# Altium schematic sheet Bumblebee.SchDoc
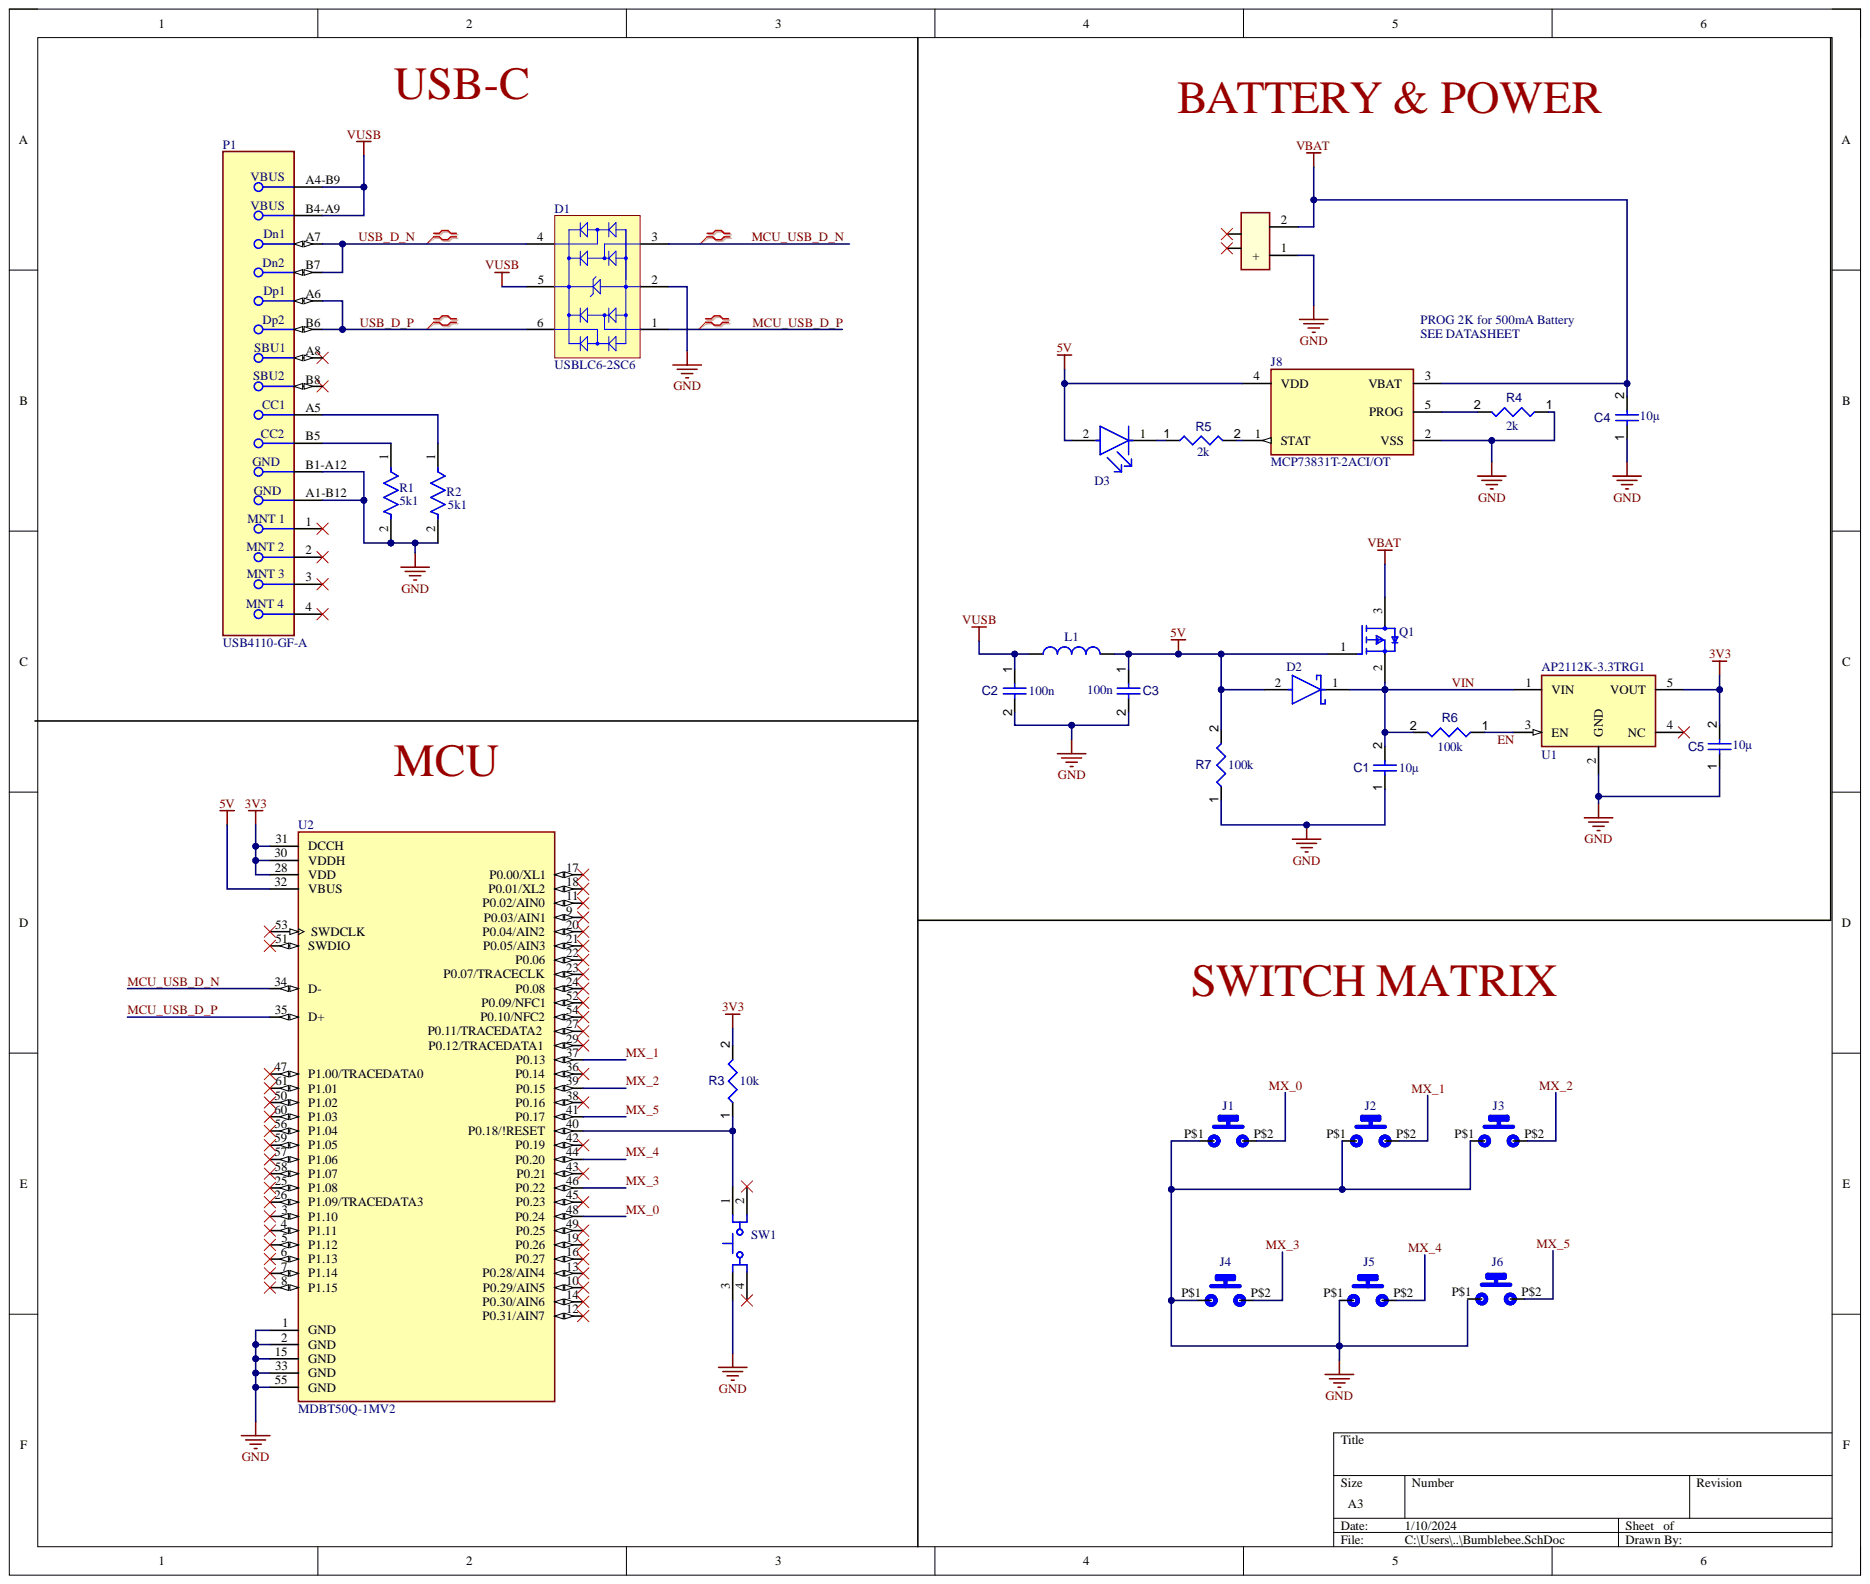
[diagram p0: Bumblebee.SchDoc]

## Parts
C1  value 10µ  [CAPC0603(1608)100_L]  (hidden comment: Capacitor 10µF +/-20% 10V 0603)
C2  value 100n  [CAPC1608X90X40NL10T20]  (hidden comment: Capacitor 100 nF +/-10% 50 V 0603)
C3  value 100n  [CAPC1608X90X40NL10T20]  (hidden comment: Capacitor 100 nF +/-10% 50 V 0603)
C4  value 10µ  [CAPC0603(1608)100_L]  (hidden comment: Capacitor 10µF +/-20% 10V 0603)
C5  value 10µ  [CAPC0603(1608)100_L]  (hidden comment: Capacitor 10µF +/-20% 10V 0603)
D1  USBLC6-2SC6  [STM-SOT23-6L_V]
D2  MBR120ESFT1G  [On_Semiconductor_498_MFG]  (value not drawn)
D3  APHCM2012SYCK-F01  [FP-APHCM2012SYCK-F01-MFG]  (value not drawn)
J1  MX1A-11NN  [CHERRY_MX1A-11NN_SWITCH]  (value not drawn)
J2  MX1A-11NN  [CHERRY_MX1A-11NN_SWITCH]  (value not drawn)
J3  MX1A-11NN  [CHERRY_MX1A-11NN_SWITCH]  (value not drawn)
J4  MX1A-11NN  [CHERRY_MX1A-11NN_SWITCH]  (value not drawn)
J5  MX1A-11NN  [CHERRY_MX1A-11NN_SWITCH]  (value not drawn)
J6  MX1A-11NN  [CHERRY_MX1A-11NN_SWITCH]  (value not drawn)
J7  T1M-02-T-SH-L-K  [SAMTEC_T1M-02-T-SH-L-K]  (value not drawn)
J8  MCP73831T-2ACI/OT  [SOT95P280X145-5N]
L1  LQG18HN2N2S00D  [FP-LQG18HH_00-IPC_A]  (value not drawn)
P1  USB4110-GF-A  [FP-USB4110-GF-A-MFG]
Q1  DMG2305UX-13  [FP-SOT23-MFG]  (value not drawn)
R1  value 5k1  [FP-ERA3A-MFG]  (hidden comment: ERA-3AEB512V)
R2  value 5k1  [FP-ERA3A-MFG]  (hidden comment: ERA-3AEB512V)
R3  value 10k  [RESC1608X55X25ML10T15]  (hidden comment: Resistor 10k +/-1% 0603 100 mW)
R4  value 2k  [RESC1608X55X25ML10T15]  (hidden comment: Resistor 100k +/-1% 0603 100 mW)
R5  value 2k  [RESC1608X55X25ML10T15]  (hidden comment: Resistor 1k +/-1% 0603 100 mW)
R6  value 100k  [RESC1608X55X25ML10T15]  (hidden comment: Resistor 100k +/-1% 0603 100 mW)
R7  value 100k  [RESC1608X55X25ML10T15]  (hidden comment: Resistor 100k +/-1% 0603 100 mW)
SW1  TL3342F160QG  [ESWI-TL3342F160QG_V]  (value not drawn)
U1  AP2112K-3.3TRG1  [SOT95P285X140-5N]
U2  MDBT50Q-1MV2  [XCVR_MDBT50Q-1MV2]

## Nets
3V3: C5.2 R3.2 U1.5(VOUT) U2.28(VDD) U2.30(VDDH) U2.31(DCCH)
5V: C3.1 D2.2 D3.2 J8.4(VDD) L1.2 Q1.1 R7.2 U2.32(VBUS)
GND: C1.1 C2.2 C3.2 C4.1 C5.1 D1.2 J1.P$1 J2.P$1 J3.P$1 J4.P$1 J5.P$1 J7.1(+) J8.2(VSS) P1.A1-B12 P1.B1-A12 R1.2 R2.2 R4.1 R7.1 SW1.3 U1.2(GND) U2.1(GND) U2.2(GND) U2.15(GND) U2.33(GND) U2.55(GND)
VBAT: C4.2 J7.2 J8.3(VBAT) Q1.3
VUSB: C2.1 D1.5 L1.1 P1.A4-B9 P1.B4-A9
EN: R6.1 U1.3(EN)
MCU_USB_D_N: D1.3 U2.34(D-)
MCU_USB_D_P: D1.1 U2.35(D+)
MX_0: J1.P$2 U2.48(P0.24)
MX_1: J2.P$2 U2.37(P0.13)
MX_2: J3.P$2 U2.39(P0.15)
MX_3: J4.P$2 U2.46(P0.22)
MX_4: J5.P$2 U2.44(P0.20)
MX_5: J6.P$2 U2.41(P0.17)
USB_D_N: D1.4 P1.A7 P1.B7
USB_D_P: D1.6 P1.A6 P1.B6
VIN: C1.2 D2.1 Q1.2 R6.2 U1.1(VIN)
NetD3_1: D3.1 R5.1
NetJ8_1: J8.1(STAT) R5.2
NetJ8_5: J8.5(PROG) R4.2
NetP1_A5: P1.A5 R2.1
NetP1_B5: P1.B5 R1.1
NetR3_1: R3.1 SW1.1 U2.40(P0.18/!RESET)

Not connected: J6 P$1; J7 S1 S2; P1 1 2 3 4 A8 B8; SW1 2 4; U1 4(NC); U2 3(P1.10) 4(P1.11) 5(P1.12) 6(P1.13) 7(P1.14) 8(P1.15) 9(P0.03/AIN1) 10(P0.29/AIN5) 11(P0.02/AIN0) 12(P0.31/AIN7) 13(P0.28/AIN4) 14(P0.30/AIN6) 16(P0.27) 17(P0.00/XL1) 18(P0.01/XL2) 19(P0.26) 20(P0.04/AIN2) 21(P0.05/AIN3) 22(P0.06) 23(P0.07/TRACECLK) 24(P0.08) 25(P1.08) 26(P1.09/TRACEDATA3) 27(P0.11/TRACEDATA2) 29(P0.12/TRACEDATA1) 36(P0.14) 38(P0.16) 42(P0.19) 43(P0.21) 45(P0.23) 47(P1.00/TRACEDATA0) 49(P0.25) 50(P1.02) 51(SWDIO) 52(P0.09/NFC1) 53(SWDCLK) 54(P0.10/NFC2) 56(P1.04) 57(P1.06) 58(P1.07) 59(P1.05) 60(P1.03) 61(P1.01)

## Library data of the parts
C1 C4 C5 = CMP-001-00075-5: Chip Capacitor, 10µF +/-20%, 10V, 0603, Thickness 1 mm; Tolerance: 10%; Case/Package: 0603; Component Kind: Standard; Max Operating Temperature: 85°C; Min Operating Temperature: -55°C; Mounting Technology: SMT; Pins: 2; Voltage Rating: 10V; Library Name: University of South Florida
C2 C3 = CMP-001-00017-6: Chip Capacitor, 100 nF, +/- 10%, 50 V, 0603 (1608 Metric); Capacitor Type: Ceramic; Voltage Rating: 50V; Case/Package: 0603; Component Kind: Standard; Max Operating Temperature: 125°C; Min Operating Temperature: -55°C; Mounting Technology: SM; Pins: 2; RoHS Compliant: True; Tolerance: 10%; Library Name: University of South Florida
D1 = CMP-2000-05378-1: Very low capacitance ESD protection, 3.5 pF, -40 to 125 degC, 6-Pin SOT23, RoHS, Tape and Reel; Manufacturer: STMicroelectronics; Capacitance Value: 3.5pF; Clamping Voltage: 17V; Configuration: Dual; Direction Type: Uni-Directional; Leakage Current: 0.15uA; Number of Elements: 1; Peak Pulse Current: 5A; Product Depth (mm): 1.75mm; Product Height (mm): 1.3mm; Product Length (mm): 3.05mm; Rad Hardened: No; Reverse Breakdown Voltage: 6V; Reverse Stand-off Voltage: 5V; Suppressor Type: DiodeArrays; Test Current (It): 1mA; Clamping Voltage (Max): 17V; Component Kind: Standard; Datasheet URL: http://www.st.com/st-web-ui/static/active/en/resource/technical/document/datasheet/CD00050750.pdf; Datasheet Version: Rev. 5, 10/2011; Leakage Current (Max): 0.15uA; Library Name: Altium Content Vault; Manufacturer URL: http://www.st.com/; Mounting: SurfaceMount; Mounting Style: SurfaceMount; Operating Temp Range: -40Cto125C; Operating Temperature Classification: Automotive; Operating Temperature Max Deg. C: 125C; Operating Temperature Min Deg. C: -40C; Package / Case: SOT-23; Package Description: 6-Pin Small Outline Transistor, Body 2.925 x 1.625 mm, Pitch 0.95 mm; Package Reference: SOT23-6L; Packaging: TapeandReel; Pin Count: 6; Polarity: Uni-Directional; Working Voltage: 5V; Working Voltage (Max): 5V
D2 = CMP-1055-00434-2: Manufacturer Lifecycle Status: ACTIVE; NexarPartId: 330787; Average Rectified Current: 1A; Element Configuration: Single; Forward Voltage: 530mV; Max Forward Surge Current (Ifsm): 40A; Max Junction Temperature (Tj): 150°C; Max Repetitive Reverse Voltage (Vrrm): 20V; Max Reverse Leakage Current: 10µA; Polarity: Standard; Component Kind: Standard; Contact Plating: Tin; Current Rating: 1A; Forward Current: 1A; Halogen Free: Halogen Free; Height: 880µm; Lead Free: Lead Free; Length: 2.9mm; Library Name: Altium Content Vault; Lifecycle Status: Production; Max Operating Temperature: 150°C; Max Reverse Voltage (DC): 20V; Max Surge Current: 40A; Min Operating Temperature: -65°C; Number of Pins: 2; Output Current: 1A; Packaging: Cut Tape; Peak Non-Repetitive Surge Current: 40A; Peak Reverse Current: 10µA; Radiation Hardening: No; REACH SVHC: No SVHC; Reverse Voltage: 20V; RoHS: Compliant; Schedule B: 8541100080; Voltage Rating (DC): 20V; Width: 1.8mm
D3 = CMP-44529-000064-1: LED YELLOW CLEAR CHIP SMD; Dominant Wavelength: 590nm; Forward Current: 20mA; Forward Voltage: 2V; Illumination Color: Green; Luminous Intensity: 150mcd; Number of LEDs: 1; Viewing Angle: 110°; Case Code (Imperial): 0805; Case Code (Metric): 2012; Case/Package: 0805; Color: Yellow; Component Kind: Standard; Height: 0.4mm; Lead Free: Lead Free; Length: 2mm; Lens Color: Clear; Lens Style: Clear; Library Name: Altium Content Vault; Max Operating Temperature: 85°C; Min Operating Temperature: -40°C; Mount: Surface Mount; Number of Pins: 2; Package Quantity: 2000; Packaging: Tape and Reel; RoHS Compliant: Yes; Series: APHCM; Test Current: 20mA; Wavelength: 590nm; Width: 1.25mm
J1-J6 = CMP-003-000001-6: Pushbutton Switch SPST-NO Keyswitch Panel Mount, Front; Case/Package: TH; Component Kind: Standard; Component Type: Standard; Library Name: University of South Florida
J7 = CMP-015-000000-2: 1mm JST Connector, surface mounted, horizontal orientation.; Availability: Unavailable; Component Kind: Standard; Component Type: Standard; Design Item ID: T1M-02-T-SH-L-K; MP: T1M-02-T-SH-L-K; Package: None; Pin Count: 2; Price: None; Source: T1M-02-T-SH-L-K.SchLib; Library Name: University of South Florida
J8 = CMP-017-000000-5: Charger IC Lithium Ion/Polymer SOT-23-5; MF: Microchip; Availability: In Stock; Check_prices: https://www.snapeda.com/parts/MCP73831T-2ACI/OT/Microchip/view-part/?ref=eda; Component Kind: Standard; Component Type: Standard; Design Item ID: MCP73831T-2ACI/OT; MP: MCP73831T-2ACI/OT; Package: SOT-23 Microchip; Pin Count: 5; Price: None; Purchase-URL: https://pricing.snapeda.com/search/part/MCP73831T-2ACI/OT/?ref=eda; SnapEDA_Link: https://www.snapeda.com/parts/MCP73831T-2ACI/OT/Microchip/view-part/?ref=snap; Source: MCP73831T-2ACI_OT.SchLib; Library Name: University of South Florida
L1 = CMP-006-00021-1: General Purpose Inductor, 0.0022uH, 13.636%, 1 Element, Air-Core, SMD, 0603; Max Current Rating: 1.1A; Self Resonant Frequency: 6GHz; Component Kind: Standard; Max Operating Temperature: 85°C; Min Operating Temperature: -40°C; Pins: 2; RoHS Compliant: Yes; Tolerance: 13.636%; Library Name: University of South Florida
P1 = CMP-002-00073-7: Conn USB Type C RCP 16 POS 0.3mm/0.5mm Solder ST SMD 16 Terminal 1 Port T/R; Component Kind: Standard; Library Name: University of South Florida
Q1 = CMP-12801-000021-1: MOSFET P-CH 20V 4.2A SOT23; Manufacturer: Diodes Inc.; Continuous Drain Current: -4.2A; Depletion Mode: False; Drain To Source Breakdown Voltage: -20V; Drain To Source Resistance: 40mΩ; Gate Charge At Vgs: 10.2nC @ -4.5V; Gate To Source Voltage: 8V; Input Capacitace At Vds: 808pF @ -15V; Max Junction Temp: +150°C; Number Of Channels: 1; Power Dissipation: 1.4W; Pulse Drain Current: -15A; Rthja Max: 90°C/W; Standoff Height: 0.013mm; Threshold Vgs Max: -0.9V; Threshold Vgs Min: -0.5V; Turn Off Delay Time: 79.3ns; Turn On Delay Time: 10.8ns; Automotive: No; Category: Trans; Component Kind: Standard; ComponentLink2Description: Datasheet; ComponentLink2URL: https://www.diodes.com/assets/Datasheets/DMG2305UX.pdf; Device Class L1: Discrete Semiconductors; Device Class L2: Transistors; Device Class L3: MOSFETs; Digikey Description: MOSFET P-CH 20V 4.2A SOT23; Drain To Source Voltage: -20V; Footprint Url: https://www.diodes.com/assets/Package-Files/SOT23.pdf; Height: 1.1mm; Ipc Land Pattern Name: SOT92P240X102-3; Lead Free: Yes; Library Name: Altium Content Vault; Manufacturer Part Number: DMG2305UX-13; Mouser Description: MOSFET P-Ch ENH FET -20V 52mOhm -5.0V; Number Of P Channels: 1; Octopart: https://octopart.com/dmg2305ux-13-diodes+inc.-29925594; Package: SOT23; RoHS: TRUE; Temperature: -55°C to +150°C; Temperature Range High: +150°C; Temperature Range Low: -55°C
R1 R2 = CMP-009-00177-2: Res Thin Film 0603 5.1K Ohm 0.1% 1/10W ±25ppm/°C Molded SMD SMD Punched Carrier T/R; Component Kind: Standard; Library Name: University of South Florida
R3 = CMP-009-00017-5: Chip Resistor, 10 KOhm, +/- 1%, 01 W, -55 to 155 degC, 0603 (1608 Metric); Power: 100mW; Voltage Rating: 50V; Case/Package: 0603; Component Kind: Standard; Max Operating Temperature: 155°C; Min Operating Temperature: -55°C; Mounting Technology: SM; Pins: 2; RoHS Compliant: True; Tolerance: 1%; Library Name: University of South Florida
R4 R6 R7 = CMP-009-00023-5: Chip Resistor, 100 KOhm, +/- 1%, 0.1 W, -55 to 155 degC, 0603 (1608 Metric); Power: 100mW; Voltage Rating: 50V; Case/Package: 0603; Component Kind: Standard; Max Operating Temperature: 155°C; Min Operating Temperature: -55°C; Mounting Technology: SM; Pins: 2; RoHS Compliant: True; Tolerance: 1%; Library Name: University of South Florida
R5 = CMP-009-00014-5: Chip Resistor, 1 KOhm, +/-1%, 0.1 W, -55 to 155 degC, 0603 (1608 Metric); Power: 100mW; Tolerance: 1%; Voltage Rating: 50V; Case/Package: 0603; Component Kind: Standard; Max Operating Temperature: 155°C; Min Operating Temperature: -55°C; Mounting Technology: SM; Pins: 2; RoHS Compliant: True; Library Name: University of South Florida
SW1 = CMP-011-00008-2: Tactile Switch, SPST-NO, 50 mA, 12 V, 4-Pin SMD, RoHS, Tape and Reel; Manufacturer: E-Switch; Current Rating: 50mA; Max Voltage Rating (DC): 12V; Operating Force: 1.6N; Throw Configuration: SPST; Case/Package: TL3342F160QG; Component Kind: Standard; Contact Material: Silver; Contact Plating: Silver; Datasheet URL: http://spec_sheets.e-switch.com/specs/P010632.pdf; Datasheet Version: Rev. I, 12/2010; Manufacturer URL: http://www.e-switch.ch/en/; Mounting Technology: SM; Package Description: 4-Pin Surface Mount Device, Body 5 x 5 mm, Pitch 6.3 mm; Package Quantity: 3000; Package Reference: TL3342F160QG; Packaging: TapeandReel; RoHS Compliant: True; Voltage Rating (DC): 12V; Library Name: University of South Florida
U1 = CMP-031-00006-3: Linear Voltage Regulator IC Positive Fixed 1 Output 600mA SOT-25; MF: Diodes Inc.; Availability: In Stock; Case/Package: SOT-753 Diodes Inc.; Check_prices: https://www.snapeda.com/parts/AP2112K-3.3TRG1/Diodes+Inc./view-part/?ref=eda; Component Kind: Standard; Component Type: Standard; Design Item ID: AP2112K-3.3TRG1; MP: AP2112K-3.3TRG1; Pins: 4; Price: None; Purchase-URL: https://pricing.snapeda.com/search/part/AP2112K-3.3TRG1/?ref=eda; SnapEDA_Link: https://www.snapeda.com/parts/AP2112K-3.3TRG1/Diodes+Inc./view-part/?ref=snap; Source: AP2112K-3.3TRG1.SchLib; Library Name: University of South Florida
U2 = CMP-011-000000-3: MF: Raytac Corporation; Availability: Warning; BuyPart: https://www.snapeda.com/parts/MDBT50Q-1MV2/Raytac%20Corporation/view-part/4593668/?ref=eda; Component Kind: Standard; Component Type: Standard; Description: MDBT50Q-1MV2; Design Item ID: MDBT50Q-1MV2; MP: MDBT50Q-1MV2; Package: None; Pin Count: 61; Price: None; SnapEDA_Link: https://www.snapeda.com/parts/MDBT50Q-1MV2/Raytac%20Corporation/view-part/4593668/?ref=snap; Source: MDBT50Q-1MV2.SchLib; Library Name: University of South Florida
